# Altium schematic sheet rpi_adapter_4lane.SchDoc
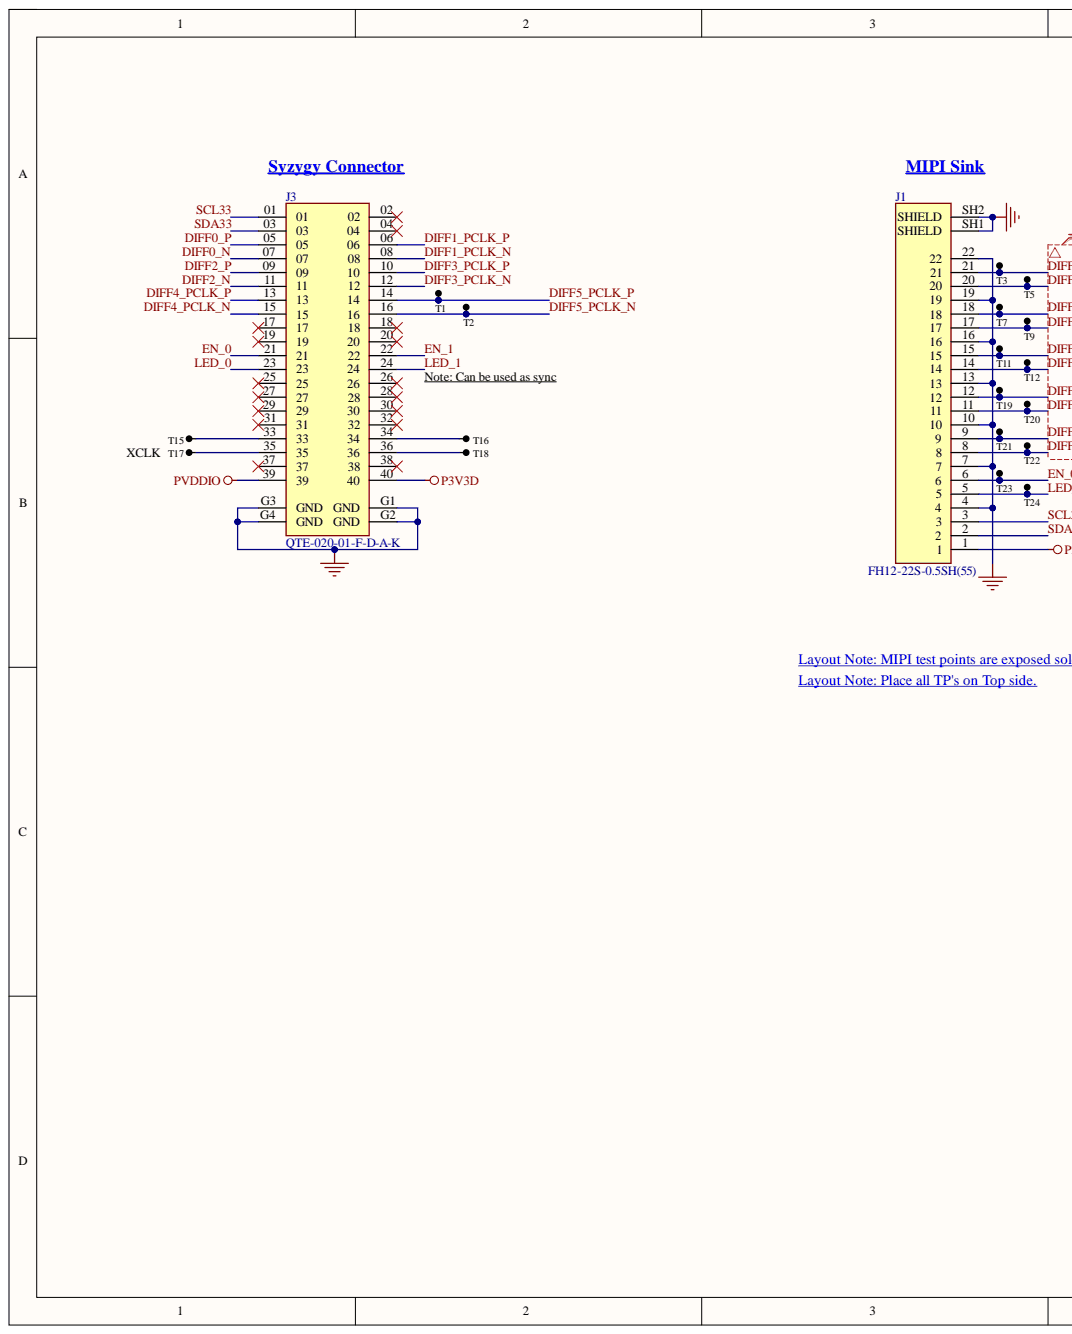
[diagram p0: rpi_adapter_4lane.SchDoc, left]
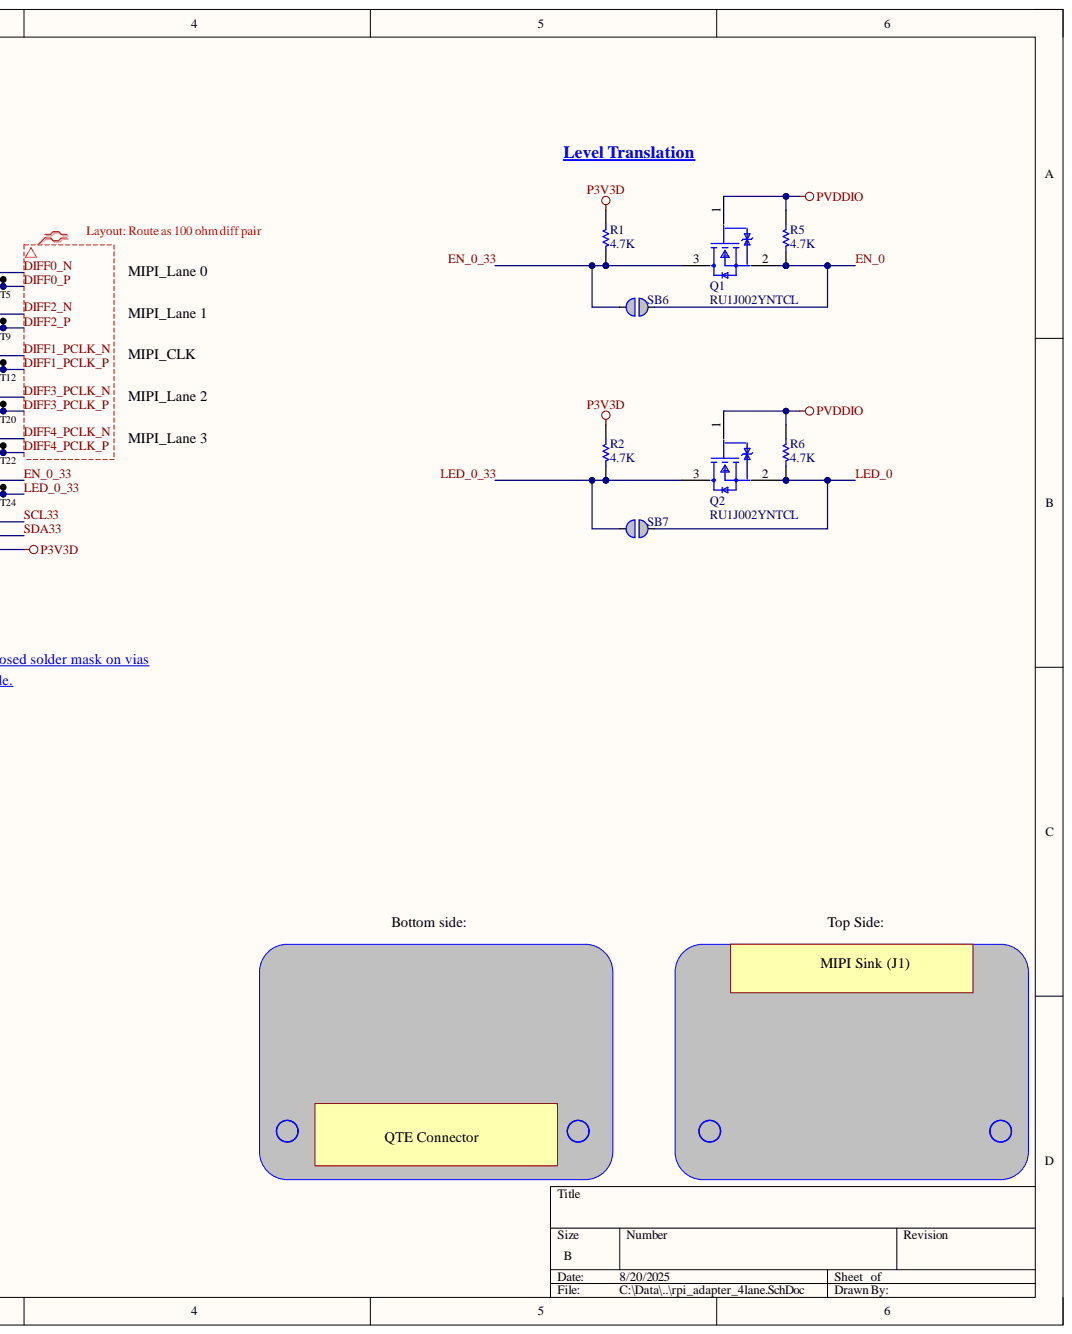
[diagram p1: rpi_adapter_4lane.SchDoc, right]

## Parts
J1  FH12-22S-0.5SH(55)  [CONN_FH12-22S-0.5SH55_HIR]
J3  QTE-020-01-F-D-A-K  [SAMTEC_QTE-020-01-X-D-A]
Q1  RU1J002YNTCL  [FP-UMT3F-MFG]
Q2  RU1J002YNTCL  [FP-UMT3F-MFG]
R1  4.7K  [RESC1005X40USR]
R2  4.7K  [RESC1005X40USR]
R5  4.7K  [RESC1005X40USR]
R6  4.7K  [RESC1005X40USR]
SB6  Solderbridge  [Solderbridge]  (value not drawn)  (no BOM)
SB7  Solderbridge  [Solderbridge]  (value not drawn)  (no BOM)
T1  TP_S  [TP 20mil]  (value not drawn)  (no BOM)
T2  TP_S  [TP 20mil]  (value not drawn)  (no BOM)
T3  TP_S  [TP 20mil]  (value not drawn)  (no BOM)
T5  TP_S  [TP 20mil]  (value not drawn)  (no BOM)
T7  TP_S  [TP 20mil]  (value not drawn)  (no BOM)
T9  TP_S  [TP 20mil]  (value not drawn)  (no BOM)
T11  TP_S  [TP 20mil]  (value not drawn)  (no BOM)
T12  TP_S  [TP 20mil]  (value not drawn)  (no BOM)
T15  TP_S  [TP 1mm]  (value not drawn)  (no BOM)
T16  TP_S  [TP 1mm]  (value not drawn)  (no BOM)
T17  TP_S  [TP 1mm]  (value not drawn)  (no BOM)
T18  TP_S  [TP 1mm]  (value not drawn)  (no BOM)
T19  TP_S  [TP 20mil]  (value not drawn)  (no BOM)
T20  TP_S  [TP 20mil]  (value not drawn)  (no BOM)
T21  TP_S  [TP 20mil]  (value not drawn)  (no BOM)
T22  TP_S  [TP 20mil]  (value not drawn)  (no BOM)
T23  TP_S  [TP 20mil]  (value not drawn)  (no BOM)
T24  TP_S  [TP 20mil]  (value not drawn)  (no BOM)

## Nets
GND: J1.4 J1.7 J1.10 J1.13 J1.16 J1.19 J1.22 J1.SH1(SHIELD) J1.SH2(SHIELD) J3.G1(GND) J3.G2(GND) J3.G3(GND) J3.G4(GND)
P3V3D: J1.1 J3.40 R1.2 R2.2
PVDDIO: J3.39 Q1.1 Q2.1 R5.2 R6.2
DIFF0_N: J1.21 J3.07 T3.1
DIFF0_P: J1.20 J3.05 T5.1
DIFF1_PCLK_N: J1.15 J3.08 T11.1
DIFF1_PCLK_P: J1.14 J3.06 T12.1
DIFF2_N: J1.18 J3.11 T7.1
DIFF2_P: J1.17 J3.09 T9.1
DIFF3_PCLK_N: J1.12 J3.12 T19.1
DIFF3_PCLK_P: J1.11 J3.10 T20.1
DIFF4_PCLK_N: J1.9 J3.15 T21.1
DIFF4_PCLK_P: J1.8 J3.13 T22.1
DIFF5_PCLK_N: J3.16 T2.1
DIFF5_PCLK_P: J3.14 T1.1
EN_0: J3.21 Q1.2 R5.1 SB6.1
EN_0_33: J1.6 Q1.3 R1.1 SB6.2 T23.1
EN_1: J3.22
LED_0: J3.23 Q2.2 R6.1 SB7.1
LED_0_33: J1.5 Q2.3 R2.1 SB7.2 T24.1
LED_1: J3.24
SCL33: J1.3 J3.01
SDA33: J1.2 J3.03
NetJ3_33: J3.33 T15.1
NetJ3_34: J3.34 T16.1
NetJ3_35: J3.35 T17.1
NetJ3_36: J3.36 T18.1

Not connected: J3 02 04 17 18 19 20 25 26 27 28 29 30 31 32 37 38

## Library data of the parts
J1 = 54548-2271: Connectors for Connecting - 0.5/1-mm Pitch FPC/FFC - FH12 Series (HIROSE ELECTRIC); Manufacturer: Molex; Manufacturer Part Number: 0545482271; Actuator Material: Polyphenylene; Contact Location: Bottom; Contact Resistance: 50mR; Current Rating: 500mA; Gender: Female; Insulation Resistance: 500MR; Mating Cycles: 20; Max Current Rating: 400mA; Max Voltage Rating (AC): 50V; Number of Contacts: 22; Pitch: 0.5mm; Wire/Cable Type: Straight; Category: FFC / FPC; Color: Beige,Brown; Component Kind: Standard; ComponentLink1Description: https://datasheet.ciiva.com/15176/getdatasheetpartid-445112-15176857.pdf?src-supplier=Verical; ComponentLink1URL: https://datasheet.ciiva.com/15176/getdatasheetpartid-445112-15176857.pdf?src-supplier=Verical; ComponentLink2Description: https://datasheet.ciiva.com/22829/0900766b80fd1ead-22829279.pdf?src-supplier=Rs; ComponentLink2URL: https://datasheet.ciiva.com/22829/0900766b80fd1ead-22829279.pdf?src-supplier=Rs; ComponentLink3Description: https://datasheet.ciiva.com/5136/ed-fh12-20140305-355586-5136585.pdf?src-supplier=Mouser; ComponentLink3URL: https://datasheet.ciiva.com/5136/ed-fh12-20140305-355586-5136585.pdf?src-supplier=Mouser; Contact Material: Bronze; Contact Plating: Gold; Depth: 5.6mm; Flammability Rating: UL94 V-0; Housing Color: Beige; Housing Material: Polyamide; Lead Free: Lead Free; Lead Pitch: 0.5mm; Length: 15.1mm; Library Name: tinyAI.SCHLIB; Material: Polyamide; Max Operating Temperature: 85°C; Min Operating Temperature: -40°C; Mounting Technology: Surface Mount,Horizontal; Number of Positions: 22; Number of Rows: 1; Orientation: Right Angle; Package: None; Package Quantity: 2000; Packaging: Tape and Reel; Pricing 2: 1=2.48, 10=1.896, 25=1.7068, 50=1.5786, 100=1.4617, 250=1.32356, 500=1.23022, 1000=1.14562, 2000=1.069, 4000=0.99966, 6000=0.96222, 10000=0.95154 (USD); Radiation Hardening: No; Reach SVHC Compliant: No; RoHS: Yes; RoHS Compliant: Yes; Series: FH12; Stock 2: 4164; Supplier 1: Digi-Key; Supplier 2: Digikey; Supplier Part Number 1: WM8828CT-ND; Supplier Part Number 2: HFJ122CT-ND; Termination: Solder; Voltage Rating: 50V; Voltage Rating (AC): 50V; Width: 15.1mm
J3 = QSE-020-01-F-D-A-K: Q Strip® High-Speed Ground Plane Header, 0.80mm Pitch; Manufacturer: Samtec; Gender: Male; Mated Stacking Heights: 5mm; Number of Contacts: 40; Pitch: 0.031inch; Category: Board to Board Connectors; Component Kind: Standard; ComponentLink1Description: https://datasheet.ciiva.com/6924/qte-xxx-xx-x-d-xxx-mkt-6924765.pdf?src-supplier=Digi-Key; ComponentLink1URL: https://datasheet.ciiva.com/6924/qte-xxx-xx-x-d-xxx-mkt-6924765.pdf?src-supplier=Digi-Key; Contact Plating: Gold; Library Name: NX Module baseboard.SchLib; Manufacturer Part Number: QTE-020-01-F-D-A; Mounting Technology: Surface Mount; Number of Positions: 40; Number of Rows: 2; Package Quantity: 1; Packaging: Tray; Pricing: 1=5.61000, 10=5.09400, 25=4.82480, 40=4.71275, 80=4.48825, 230=3.92726, 440=3.81505, 945=3.25402, 2400=3.02960 (USD); RoHS: Yes; RoHS Compliant: Yes; Series: QStrip® QTE; Stock: 179; Supplier 1: Digi-Key; Supplier Part Number 1: SAM8846-ND; Termination: Solder
Q1 Q2 = 1926738d861a973c8d722c53ec8fd09: MOSFET N-CH 50V 0.2A UMT3F; Manufacturer: Rohm; Continuous Drain Current: 200mA; Depletion Mode: False; Drain To Source Resistance: 1.6Ω; Gate To Source Voltage: 8V; Input Capacitace At Vds: 26pF @ 10V; Max Forward Diode Voltage: 1.2V; Max Junction Temp: +150°C; Number Of Channels: 1; Power Dissipation: 150mW; Pulse Drain Current: 800mA; Rthja Max: 833°C/W; Standoff Height: 0mm; Threshold Vgs Max: 0.8V; Threshold Vgs Min: 0.3V; Turn Off Delay Time: 17ns; Turn On Delay Time: 5ns; Automotive: No; Category: Trans; Component Kind: Standard; ComponentLink2Description: Datasheet; ComponentLink2URL: https://d1d2qsbl8m0m72.cloudfront.net/en/products/databook/datasheet/discrete/transistor/mosfet/ru1j002yn-e.pdf; Device Class L1: Discrete Semiconductors; Device Class L2: Transistors; Device Class L3: MOSFETs; Digikey Description: MOSFET N-CH 50V 0.2A UMT3F; Drain To Source Breakdown Voltage: 50V; Drain To Source Voltage: 50V; Height: 1.05mm; Lead Free: Yes; Library Name: rpi_adapter.SCHLIB; Manufacturer Part Number: RU1J002YNTCL; Mouser Description: MOSFET 0.9V Drive Nch MOSFET; Number Of N Channels: 1; Octopart: https://octopart.com/ru1j002yntcl-rohm-47197697; Package: UMT3F; RoHS: TRUE; Temperature: to +150°C; Temperature Range High: +150°C
R1 R2 R5 R6 = RES 4.7K OHM 1% 1/20W 0201: RES 4.7K OHM 1% 1/16W 0402; Manufacturer: Yageo; Manufacturer Part Number: RC0402FR-074K7L; Component Kind: Standard; Height: 0.4mm; Library Name: Smart Camera v2.SCHLIB; Package: 0402; Supplier 1: Digi-Key; Supplier Part Number 1: 311-4.7KLRCT-ND; value: 4.7K
SB6 SB7 = Solderbridge: Artikkelnr Noca: N.A.; Artikkelnr Simpro: N.A.; Description: Solderbridge; Footprint Doc: Solderbridge; LatestRevisionDate: 2009-12-14; Library Name: AiC.SCHLIB; Part Number: Solderbridge; Pin Count: 2; Published: 2009-12-14; Value: Solderbridge; Publisher: Nordic Semiconductor
T1-T3 T5 T7 T9 T11 T12 T15-T24 = TP_S: test point
  T15-T18: Library Name: AiC.SCHLIB
  T1-T3 T5 T7 T9 T11 T12 T19-T24: Library Name: AiC RVP Adapter.SCHLIB
